# Altium schematic sheet PWR1.SchDoc(PWR1)
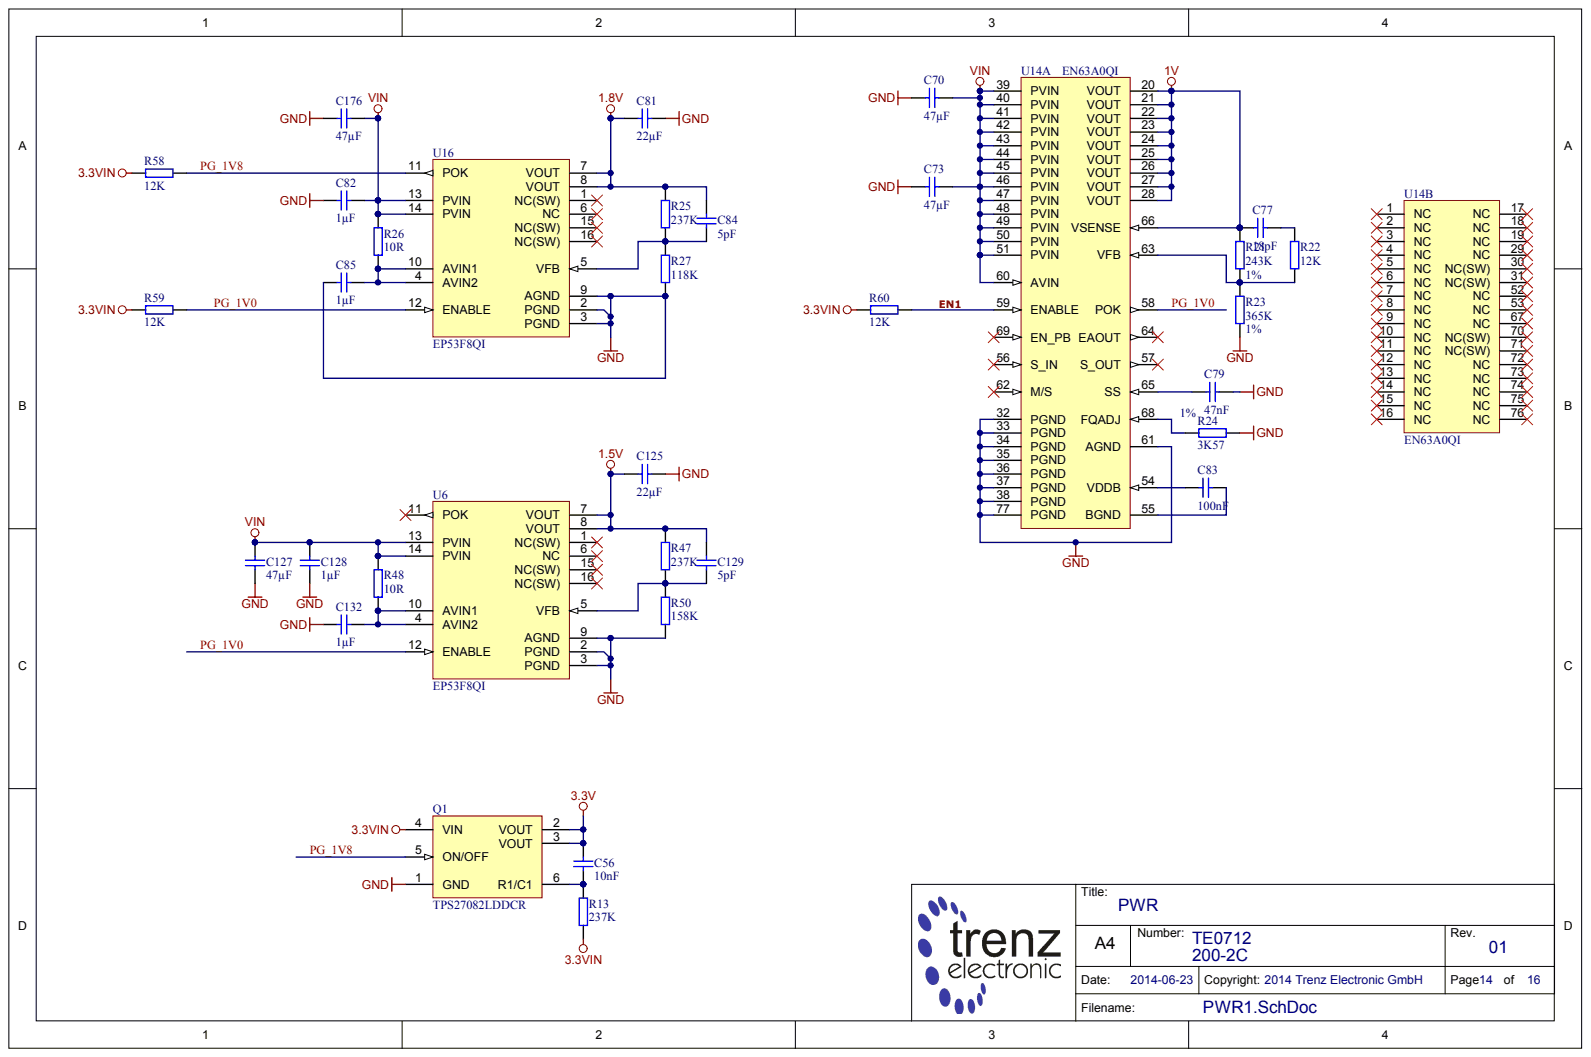
[diagram p0: PWR1.SchDoc]

## Parts
C56  10nF
C70  47µF
C73  47µF
C77  18pF
C79  47nF
C81  22µF
C82  1µF
C83  100nF
C84  5pF
C85  1µF
C125  22µF
C127  47µF
C128  1µF
C129  5pF
C132  1µF
C176  47µF
Q1  TPS27082LDDCR
R13  237K
R21  243K  Tolerance: 1%
R22  12K
R23  365K  Tolerance: 1%
R24  3K57  Tolerance: 1%
R25  237K
R26  10R
R27  118K
R47  237K
R48  10R
R50  158K
R58  12K
R59  12K
R60  12K
U6  EP53F8QI
U14  EN63A0QI  (parts A,B)
U16  EP53F8QI

## Nets
1.5V: C125.1 C129.1 R47.1 U6.7(VOUT) U6.8(VOUT)
1.8V: C81.1 C84.1 R25.1 U16.7(VOUT) U16.8(VOUT)
1V: C77.1 R21.1 U14.20(VOUT) U14.21(VOUT) U14.22(VOUT) U14.23(VOUT) U14.24(VOUT) U14.25(VOUT) U14.26(VOUT) U14.27(VOUT) U14.28(VOUT) U14.66(VSENSE)
3.3V: C56.1 Q1.2(VOUT) Q1.3(VOUT)
3.3VIN: Q1.4(VIN) R13.2 R58.2 R59.2 R60.2
GND: C70.1 C73.1 C79.2 C81.2 C82.1 C85.1 C125.2 C127.1 C128.1 C132.1 C176.2 Q1.1(GND) R23.2 R24.2 R27.2 R50.2 U6.2(PGND) U6.3(PGND) U6.9(AGND) U14.32(PGND) U14.33(PGND) U14.34(PGND) U14.35(PGND) U14.36(PGND) U14.37(PGND) U14.38(PGND) U14.61(AGND) U14.77(PGND) U16.2(PGND) U16.3(PGND) U16.9(AGND)
VIN: C70.2 C73.2 C82.2 C127.2 C128.2 C176.1 R26.1 R48.1 U6.13(PVIN) U6.14(PVIN) U14.39(PVIN) U14.40(PVIN) U14.41(PVIN) U14.42(PVIN) U14.43(PVIN) U14.44(PVIN) U14.45(PVIN) U14.46(PVIN) U14.47(PVIN) U14.48(PVIN) U14.49(PVIN) U14.50(PVIN) U14.51(PVIN) U14.60(AVIN) U16.13(PVIN) U16.14(PVIN)
EN1: R60.1 U14.59(ENABLE)
PG_1V0: R59.1 U6.12(ENABLE) U14.58(POK) U16.12(ENABLE)
PG_1V8: Q1.5(ON/OFF) R58.1 U16.11(POK)
NetC56_2: C56.2 Q1.6(R1/C1) R13.1
NetC77_2: C77.2 R22.1
NetC79_1: C79.1 U14.65(SS)
NetC83_1: C83.1 U14.54(VDDB)
NetC83_2: C83.2 U14.55(BGND)
NetC84_2: C84.2 R25.2 R27.1 U16.5(VFB)
NetC85_2: C85.2 R26.2 U16.4(AVIN2) U16.10(AVIN1)
NetC129_2: C129.2 R47.2 R50.1 U6.5(VFB)
NetC132_2: C132.2 R48.2 U6.4(AVIN2) U6.10(AVIN1)
NetR21_2: R21.2 R22.2 R23.1 U14.63(VFB)
NetR24_1: R24.1 U14.68(FQADJ)

Not connected: U6 1(NC(SW)) 6(NC) 11(POK) 15(NC(SW)) 16(NC(SW)); U14 1(NC) 2(NC) 3(NC) 4(NC) 5(NC) 6(NC) 7(NC) 8(NC) 9(NC) 10(NC) 11(NC) 12(NC) 13(NC) 14(NC) 15(NC) 16(NC) 17(NC) 18(NC) 19(NC) 29(NC) 30(NC(SW)) 31(NC(SW)) 52(NC) 53(NC) 56(S_IN) 57(S_OUT) 62(M/S) 64(EAOUT) 67(NC) 69(EN_PB) 70(NC(SW)) 71(NC(SW)) 72(NC) 73(NC) 74(NC) 75(NC) 76(NC); U16 1(NC(SW)) 6(NC) 15(NC(SW)) 16(NC(SW))

## Library data of the parts
C56 = (no symbol name): AN: 24922; Value: 10n; Voltage: 6.3V; Mixed: SMT; Preferred: Yes; Case: 0603 (0201); Technology: X5R
C70 C73 C127 C176 = (no symbol name): AN: 24718; Value: 47u; Voltage: 6.3V; Mixed: SMT; Tolerance: 20%; Case: 2012 (0805); Technology: X5R
C77 = (no symbol name): AN: 24228; Value: 18p; Voltage: 50V; Mixed: SMT; Case: 1005 (0402); Technology: NPO
C79 = (no symbol name): AN: 24924; Value: 47n; Voltage: 6.3V; Mixed: SMT; Preferred: Yes; Case: 0603 (0201); Technology: X5R
C81 C125 = (no symbol name): AN: 23410; Voltage: 6.3V; Mixed: SMT; Value: 22u; Technology: X5R; Case: 2012 (0805)
C82 C85 C128 C132 = (no symbol name): AN: 23453; Value: 1u; Voltage: 6.3; Mixed: SMT; Preferred: Yes; Case: 1005 (0402); Technology: X5R
C83 = (no symbol name): AN: 24915; Value: 100n; Voltage: 6.3V; Mixed: SMT; Preferred: Yes; Case: 0603 (0201); Technology: X5R
C84 C129 = (no symbol name): AN: 24919; Value: 5p; Voltage: 6.3V; Mixed: SMT; Case: 0603 (0201); Technology: X5R
Q1 = (no symbol name): AN: 25004; Trenz-Stock: Yes; Mixed: SMT; Case: SOT23-6; Preferred: Yes; HelpURL: B:\AD\adlib\datasheet\TPS27082L
R13 R25 R47 = (no symbol name): AN: 24549; Mixed: SMT; Preferred: Yes; Case: 1005 (0402); Value: 237K
R21 = (no symbol name): AN: 25966; Mixed: SMT; Case: 1005 (0402); Value: 243K
R22 R58-R60 = (no symbol name): AN: 22598; Mixed: SMT; Preferred: Yes; Case: 1005 (0402); Value: 12K
R23 = (no symbol name): AN: 25967; Mixed: SMT; Preferred: Yes; Case: 1005 (0402); Value: 365K
R24 = (no symbol name): AN: 23145; Value: 3.57K; Mixed: SMT; Case: 1005 (0402)
R26 R48 = (no symbol name): AN: 22844; Value: 10; Mixed: SMT; Preferred: Yes; Case: 1005 (0402)
R27 = (no symbol name): AN: 24713; Mixed: SMT; Preferred: Yes; Case: 1005 (0402); Value: 118K
R50 = (no symbol name): AN: 24550; Mixed: SMT; Preferred: Yes; Case: 1005 (0402); Value: 158k
U6 U16 = (no symbol name): AN: 24487; Trenz-Stock: Yes; Mixed: SMT; Preferred: Yes; HelpURL: B:\AD\adlib\datasheet\EP53F8QI
U14 = (no symbol name): AN: 24937; Trenz-Stock: Yes; Mixed: SMT; Preferred: Yes; HelpURL: B:\AD\adlib\datasheet\EN63A0QI
